# Altium schematic sheet Power.SchDoc(Power)
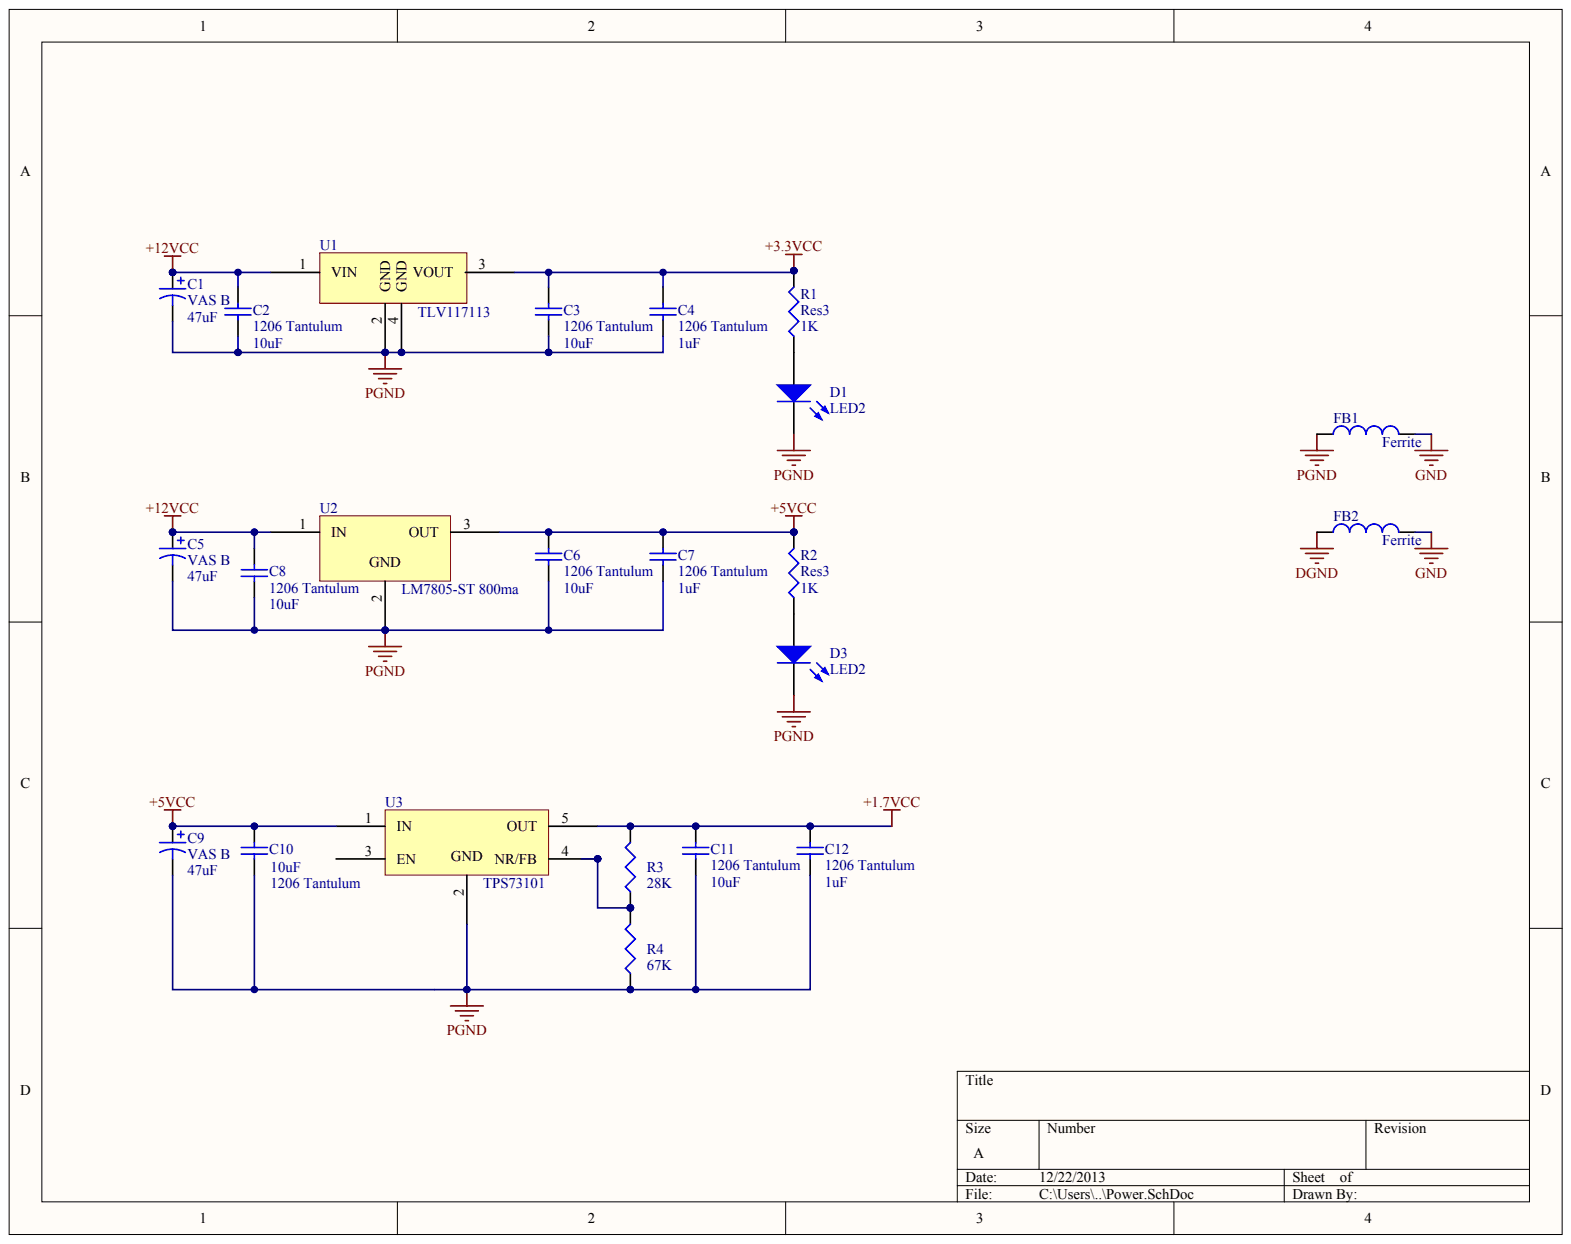
[diagram p0: Power.SchDoc]

## Parts
C1  VAS B  value 47uF
C2  1206 Tantulum  value 10uF
C3  1206 Tantulum  value 10uF
C4  1206 Tantulum  value 1uF
C5  VAS B  value 47uF
C6  1206 Tantulum  value 10uF
C7  1206 Tantulum  value 1uF
C8  1206 Tantulum  value 10uF
C9  VAS B  value 47uF
C10  1206 Tantulum  value 10uF
C11  1206 Tantulum  value 10uF
C12  1206 Tantulum  value 1uF
D1  LED2
D3  LED2
FB1  Ferrite
FB2  Ferrite
R1  Res3  value 1K
R2  Res3  value 1K
R3  value 28K  (hidden comment: Res3)
R4  value 67K  (hidden comment: Res3)
U1  TLV117113
U2  LM7805-ST 800ma
U3  TPS73101

## Nets
+1.7VCC: C11.2 C12.2 R3.2 U3.5(OUT)
+3.3VCC: C3.2 C4.2 R1.2 U1.3(VOUT)
+5VCC: C6.2 C7.2 C9.1 C10.2 R2.2 U2.3 U3.1(IN)
+12VCC: C1.1 C2.2 C5.1 C8.2 U1.1(VIN) U2.1
DGND: FB2.1
GND: FB1.2 FB2.2
PGND: C1.2 C2.1 C3.1 C4.1 C5.2 C6.1 C7.1 C8.1 C9.2 C10.1 C11.1 C12.1 D1.2 D3.2 FB1.1 R4.1 U1.2(GND) U1.4(GND) U2.2 U3.2
NetD1_1: D1.1 R1.1
NetD3_1: D3.1 R2.1
NetR3_1: R3.1 R4.2 U3.4(NR/FB)

Not connected: U3 3(EN)

## Library data of the parts
FB1 FB2 = (no symbol name): Code_IEC: 1005; Published: 8-Jun-2000; LatestRevisionDate: 17-Jul-2002; LatestRevisionNote: Re-released for DXP Platform.; PackageReference: 0402-A; Code_JEITA: 0402; Publisher: Altium Limited
C1-C12 D1 D3 R1-R4 U1-U3 = (no symbol name)
  C1 C5 C9: Published: 8-Jun-2000; LatestRevisionDate: 17-Jul-2002; LatestRevisionNote: Re-released for DXP Platform.; PackageReference: RB7.6-15; PackageDescription: Polarized Capacitor (Radial); 2 Leads
  C2-C4 C6-C8 C10-C12: Published: 8-Jun-2000; LatestRevisionDate: 29-May-2009; LatestRevisionNote: IPC-7351 Footprint Added.; PackageReference: C1206; PackageDescription: Chip Capacitor
  D1 D3: Published: 8-Jun-2000; LatestRevisionDate: 17-Jul-2002; LatestRevisionNote: Re-released for DXP Platform.; PackageVersion: Feb-2002; PackageReference: 3.2X1.6X1.1; PackageDescription: SM LED; 2 Flat Leads
  R1-R4: Published: 8-Jun-2000; LatestRevisionDate: 29-May-2009; LatestRevisionNote: IPC-7351 Footprint Added.; PackageReference: J1-0603; PackageDescription: Chip Resistor
  C1-C12 D1 D3 R1-R4: Publisher: Altium Limited
